# Altium schematic sheet Power.SchDoc
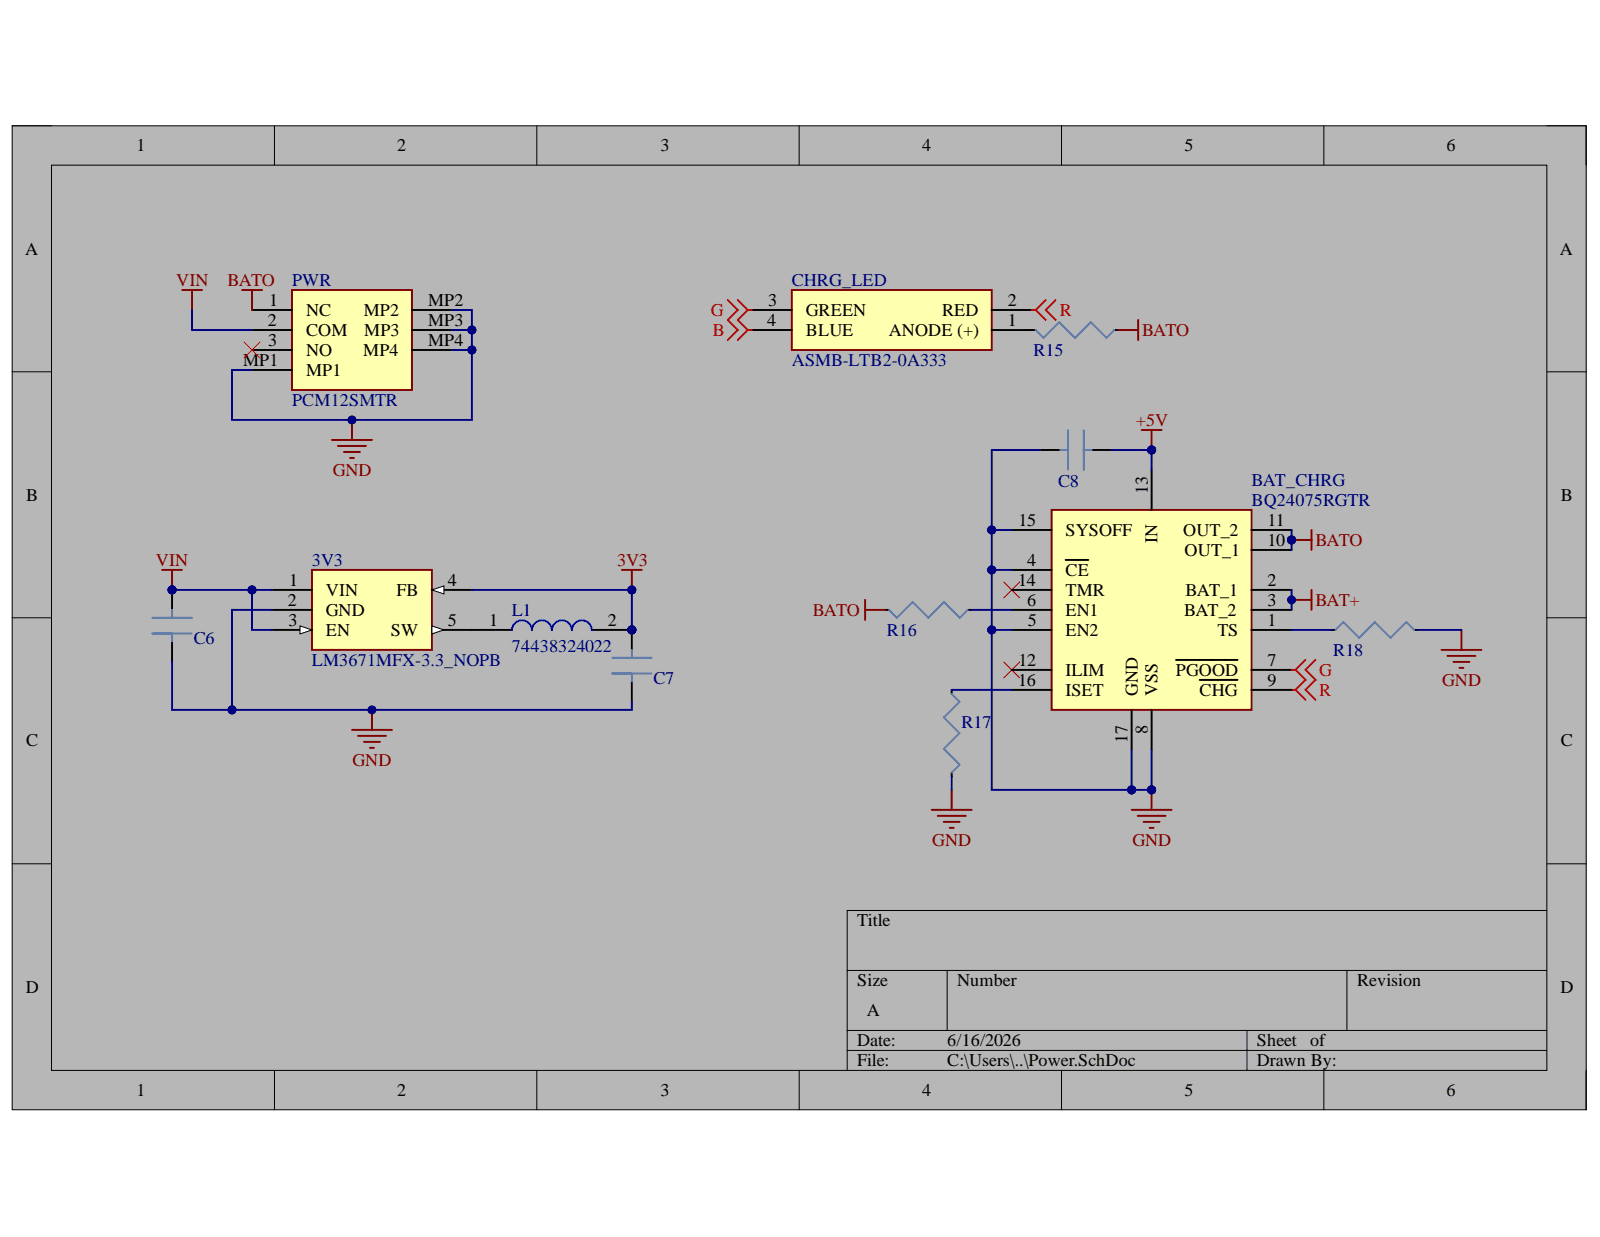
[diagram p0: Power.SchDoc]

## Parts
3V3  LM3671MFX-3.3_NOPB  [SOT95P280X145-5N]
BAT_CHRG  BQ24075RGTR  [QFN50P300X300X100-17N-D]
C6  4.7uF 0402  [0402 Cap]  (value not drawn)
C7  10uF 0402  [0402 Cap]  (value not drawn)
C8  4.7uF 0402  [0402 Cap]  (value not drawn)
CHRG_LED  ASMB-LTB2-0A333  [ASMBKTF00A306]
L1  74438324022  [INDC2520X120N]
PWR  PCM12SMTR  [PCM12SMTR]
R15  10K Ohm 5% 0402  [0402_RESISTOR]  (value not drawn)
R16  10K Ohm 5% 0402  [0402_RESISTOR]  (value not drawn)
R17  2K Ohm 5% 0402  [0402_RESISTOR]  (value not drawn)
R18  10K Ohm 5% 0402  [0402_RESISTOR]  (value not drawn)

## Nets
3V3: 3V3.4(FB) C7.1 L1.2
+5V: BAT_CHRG.13(IN) C8.1
B: CHRG_LED.4(BLUE)
BAT+: BAT_CHRG.2(BAT_1) BAT_CHRG.3(BAT_2)
BATO: BAT_CHRG.10(OUT_1) BAT_CHRG.11(OUT_2) PWR.1(NC) R15.1 R16.1
G: BAT_CHRG.7(PGOOD) CHRG_LED.3(GREEN)
GND: 3V3.2(GND) BAT_CHRG.4(CE) BAT_CHRG.5(EN2) BAT_CHRG.8(VSS) BAT_CHRG.15(SYSOFF) BAT_CHRG.17(GND) C6.2 C7.2 C8.2 PWR.MP1 PWR.MP2 PWR.MP3 PWR.MP4 R17.1 R18.1
R: BAT_CHRG.9(CHG) CHRG_LED.2(RED)
VIN: 3V3.1(VIN) 3V3.3(EN) C6.1 PWR.2(COM)
Net3V3_5: 3V3.5(SW) L1.1
NetBAT_CHRG_1: BAT_CHRG.1(TS) R18.2
NetBAT_CHRG_6: BAT_CHRG.6(EN1) R16.2
NetBAT_CHRG_16: BAT_CHRG.16(ISET) R17.2
NetCHRG_LED_1: CHRG_LED.1(ANODE_(+)) R15.2

Not connected: BAT_CHRG 12(ILIM) 14(TMR); PWR 3(NO)

## Library data of the parts
3V3 = LM3671MFX-3.3_NOPB: Power Supply; Manufacturer_Name: Texas Instruments; Manufacturer_Part_Number: LM3671MFX-3.3/NOPB; Arrow Part Number: LM3671MFX-3.3/NOPB; Arrow Price/Stock: https://www.arrow.com/en/products/lm3671mfx-3.3nopb/texas-instruments?utm_currency=USD&region=nac; Component Kind: Standard; Datasheet Link: http://www.ti.com/lit/gpn/lm3671; Height: 1.45mm; Library Name: SamacSys.SchLib; Mouser Part Number: 926-LM3671MFX33NOPB; Mouser Price/Stock: https://www.mouser.co.uk/ProductDetail/Texas-Instruments/LM3671MFX-3.3-NOPB?qs=QbsRYf82W3FUz5snoO7qFA%3D%3D
BAT_CHRG = BQ24075RGTR: Integrated Circuit; Manufacturer_Name: Texas Instruments; Arrow Part Number: BQ24075RGTR; Arrow Price/Stock: https://www.arrow.com/en/products/bq24075rgtr/texas-instruments?region=nac; Component Kind: Standard; Datasheet Link: http://www.ti.com/lit/ds/symlink/bq24075.pdf; Height: 1mm; Library Name: SamacSys.SchLib; Manufacturer_Part_Number: BQ24075RGTR; Mouser Part Number: 595-BQ24075RGTR; Mouser Price/Stock: https://www.mouser.co.uk/ProductDetail/Texas-Instruments/BQ24075RGTR?qs=XGzIaZb%2FFYKTADIJv746hA%3D%3D
C6-C8 = Cap_0402: Component Kind: Standard; Library Name: custom.SchLib
CHRG_LED = ASMB-LTB2-0A333: LED; Manufacturer_Name: Avago Technologies; Component Kind: Standard; Datasheet Link: https://datasheet.datasheetarchive.com/originals/distributors/Datasheets_SAMA/917cf2351e48680b6b301963fbcffbaa.pdf; Height: 2.1mm; Library Name: SamacSys.SchLib; Manufacturer_Part_Number: ASMB-LTB2-0A333; Mouser Part Number: 630-ASMB-LTB2-0A333; Mouser Price/Stock: https://www.mouser.co.uk/ProductDetail/Broadcom-Avago/ASMB-LTB2-0A333?qs=PqoDHHvF64%2F%2FHHzowsCTZg%3D%3D
L1 = 74438324022: Inductor; Manufacturer_Name: Wurth Elektronik; Component Kind: Standard; Datasheet Link: http://katalog.we-online.de/pbs/datasheet/74438324022.pdf; Height: 1.2mm; Manufacturer_Part_Number: 74438324022; Mouser Part Number: 710-74438324022; Mouser Price/Stock: https://www.mouser.co.uk/ProductDetail/Wurth-Elektronik/74438324022?qs=iC14BvcgY%2F20ke0FzvM4WA%3D%3D
PWR = PCM12SMTR: Switch; Manufacturer_Name: C & K COMPONENTS; Arrow Part Number: PCM12SMTR; Arrow Price/Stock: https://www.arrow.com/en/products/pcm12smtr/ck?utm_currency=USD&region=nac; Component Kind: Standard; Datasheet Link: https://www.ckswitches.com/media/1424/pcm.pdf; Height: 1.4mm; Library Name: SamacSys.SchLib; Manufacturer_Part_Number: PCM12SMTR; Mouser Part Number: 611-PCM12SMTR; Mouser Price/Stock: https://www.mouser.co.uk/ProductDetail/CK/PCM12SMTR?qs=mfFuHy8STfL3qrPSfCHA7w%3D%3D
R15-R18 = Resistor_0402: Component Kind: Standard; Library Name: custom.SchLib
